# Altium schematic sheet HSS_Module.SchDoc
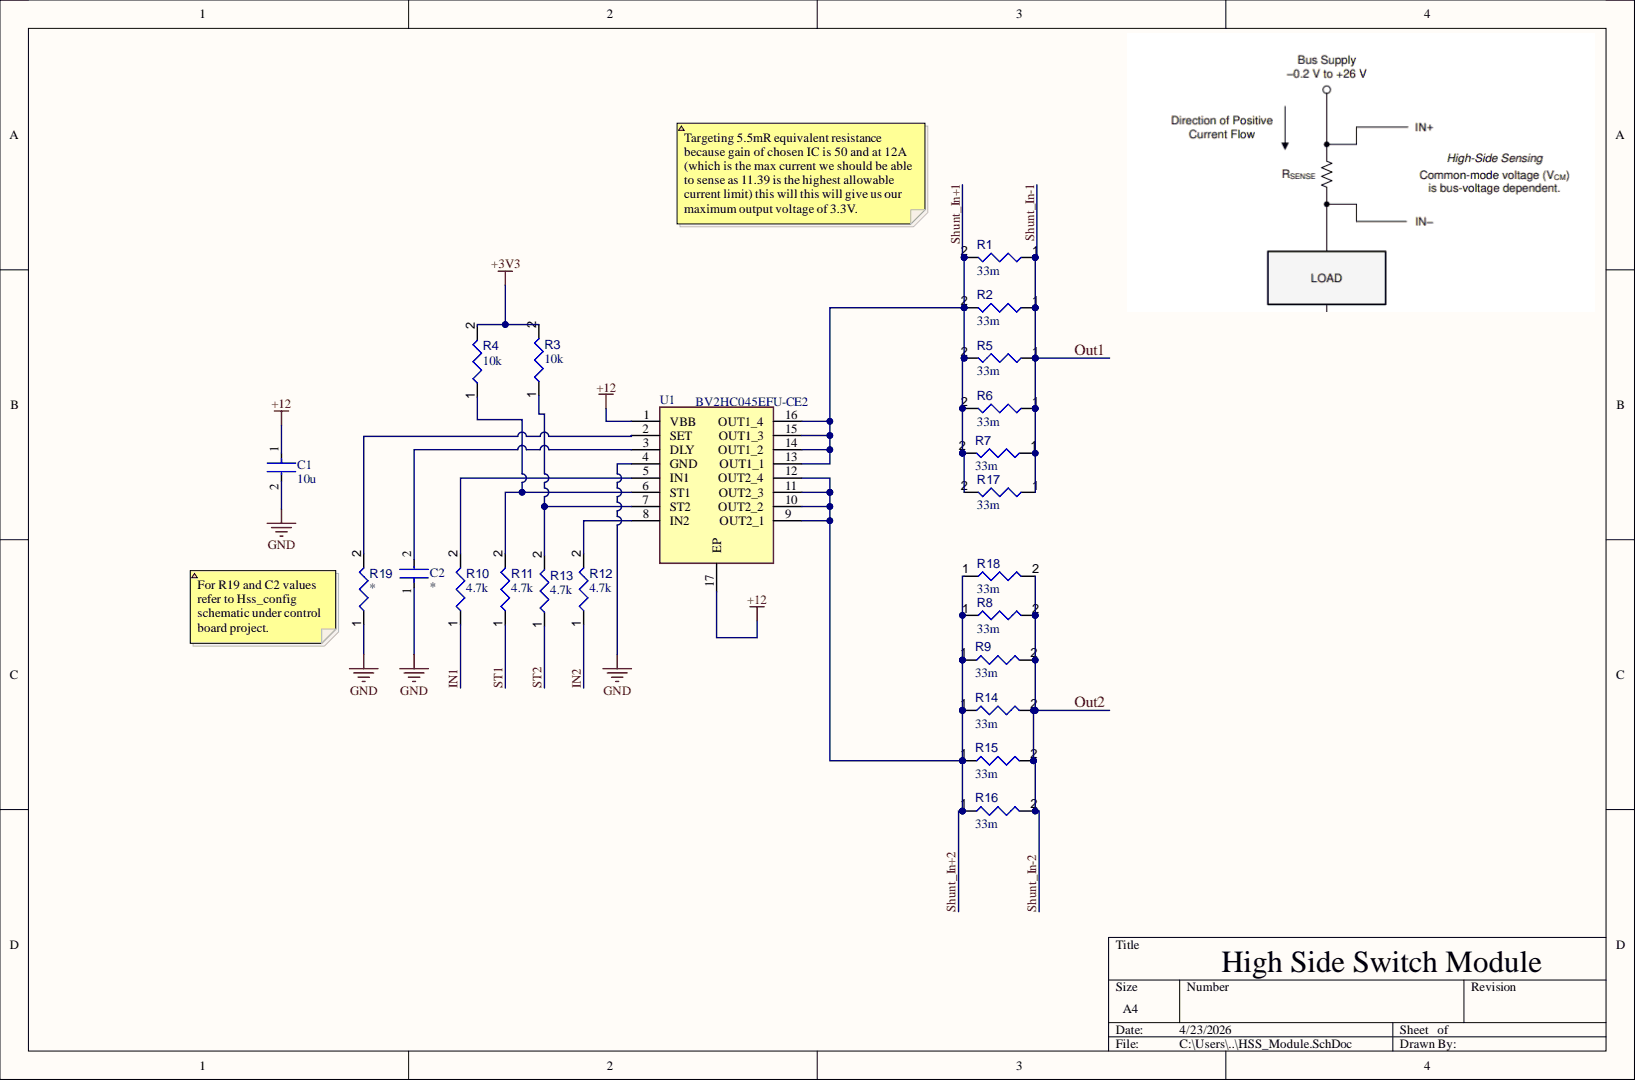
[diagram p0: HSS_Module.SchDoc]

## Parts
C1  10u  [FP-0603-L_1_6_0_15-W_0_8-IPC_B]
C2  (no value)  [FP-0603-L_1_6_0_15-W_0_8-IPC_B]
R1  value 33m  [0603-R]  (hidden comment: 0603 Resistor)
R2  value 33m  [0603-R]  (hidden comment: 0603 Resistor)
R3  value 10k  [0603-R]  (hidden comment: 0603 Resistor)
R4  value 10k  [0603-R]  (hidden comment: 0603 Resistor)
R5  value 33m  [0603-R]  (hidden comment: 0603 Resistor)
R6  value 33m  [0603-R]  (hidden comment: 0603 Resistor)
R7  value 33m  [0603-R]  (hidden comment: 0603 Resistor)
R8  value 33m  [0603-R]  (hidden comment: 0603 Resistor)
R9  value 33m  [0603-R]  (hidden comment: 0603 Resistor)
R10  value 4.7k  [0603-R]  (hidden comment: 0603 Resistor)
R11  value 4.7k  [0603-R]  (hidden comment: 0603 Resistor)
R12  value 4.7k  [0603-R]  (hidden comment: 0603 Resistor)
R13  value 4.7k  [0603-R]  (hidden comment: 0603 Resistor)
R14  value 33m  [0603-R]  (hidden comment: 0603 Resistor)
R15  value 33m  [0603-R]  (hidden comment: 0603 Resistor)
R16  value 33m  [0603-R]  (hidden comment: 0603 Resistor)
R17  value 33m  [0603-R]  (hidden comment: 0603 Resistor)
R18  value 33m  [0603-R]  (hidden comment: 0603 Resistor)
R19  0603 Resistor  [0603-R]  (value not drawn)
U1  BV2HC045EFU-CE2  [SOP50P600X170-17N]

## Nets
+3V3: R3.2 R4.2
+12: C1.1 U1.1(VBB) U1.17(EP)
GND: C1.2 C2.1 R19.1 U1.4(GND)
IN1: R10.1
IN2: R12.1
Out1: R1.1 R2.1 R5.1 R6.1 R7.1 R17.1
Out2: R8.2 R9.2 R14.2 R15.2 R16.2 R18.2
Shunt_In+1: R1.2 R2.2 R5.2 R6.2 R7.2 R17.2 U1.13(OUT1_1) U1.14(OUT1_2) U1.15(OUT1_3) U1.16(OUT1_4)
Shunt_In+2: R8.1 R9.1 R14.1 R15.1 R16.1 R18.1 U1.9(OUT2_1) U1.10(OUT2_2) U1.11(OUT2_3) U1.12(OUT2_4)
ST1: R11.1
ST2: R13.1
NetC2_2: C2.2 U1.3(DLY)
NetR3_1: R3.1 R13.2 U1.7(ST2)
NetR4_1: R4.1 R11.2 U1.6(ST1)
NetR10_2: R10.2 U1.5(IN1)
NetR12_2: R12.2 U1.8(IN2)
NetR19_2: R19.2 U1.2(SET)

## Library data of the parts
C1 C2 = CMP-2000-07531-4: Ceramic Capacitor General Use 1uF ±10% 50V X7R 0603; Manufacturer: Taiyo Yuden; Manufacturer Part Number: UMK107AB7105KA-T; Case Code (Imperial): 0603; Case Code (Metric): 1608; Case/Package: 0603; Component Kind: Standard; Construction: Flat; Depth: 0.8mm; Dielectric: X7R; Halogen Free: Halogen Free; Height: 0.8mm; Length: 1.6mm; Max Operating Temperature: 125°C; Min Operating Temperature: -55°C; Mount: Surface Mount; Package Quantity: 1; Packaging: Tape and Reel; Radiation Hardening: No; RoHS Compliant: Yes; Series: M; Termination: SMD/SMT; Thickness: 0.037inch; Tolerance: 10%; Voltage Rating: 50V; Voltage Rating (DC): 50V; Width: 0.031inch; Library Name: ePDM_V0.0.SCHLIB
  C1: Capacitance: 10u
R1-R19 = CMP-009-00014-5_3: Manufacturer: Yageo; Manufacturer Part Number: RC0603FR-071KL; Power: 100mW; Tolerance: 1%; Voltage Rating: 50V; Case/Package: 0603; Component Kind: Standard; Component Type: Resistors; Description: 0603 Resistor; Max Operating Temperature: 155°C; Min Operating Temperature: -55°C; Mounting Technology: SM; Pins: 2; RoHS Compliant: True; Library Name: ePDM_V0.0.SCHLIB
U1 = CMP-012-000000-1: POWER LOAD SW, HIGH SIDE, -40 TO 150DEGC ROHS COMPLIANT: YES; Manufacturer_Name: ROHM Semiconductor; Geometry.Height: 1.7mm; Component Kind: Standard; Datasheet Link: https://datasheet.datasheetarchive.com/originals/distributors/Datasheets_SAMA/16e4cabbfa36e38f206009e143125ffd.pdf; Design Item ID: BV2HC045EFU-CE2; Manufacturer_Part_Number: BV2HC045EFU-CE2; Mouser Part Number: 755-BV2HC045EFU-CE2; Mouser Price/Stock: https://www.mouser.co.uk/ProductDetail/ROHM-Semiconductor/BV2HC045EFU-CE2?qs=BJlw7L4Cy78Ni1shRUHVGg%3D%3D; Source: BV2HC045EFU-CE2.SchLib; Library Name: ePDM_V0.0.SCHLIB
